# Altium schematic sheet bus_filter.SchDoc
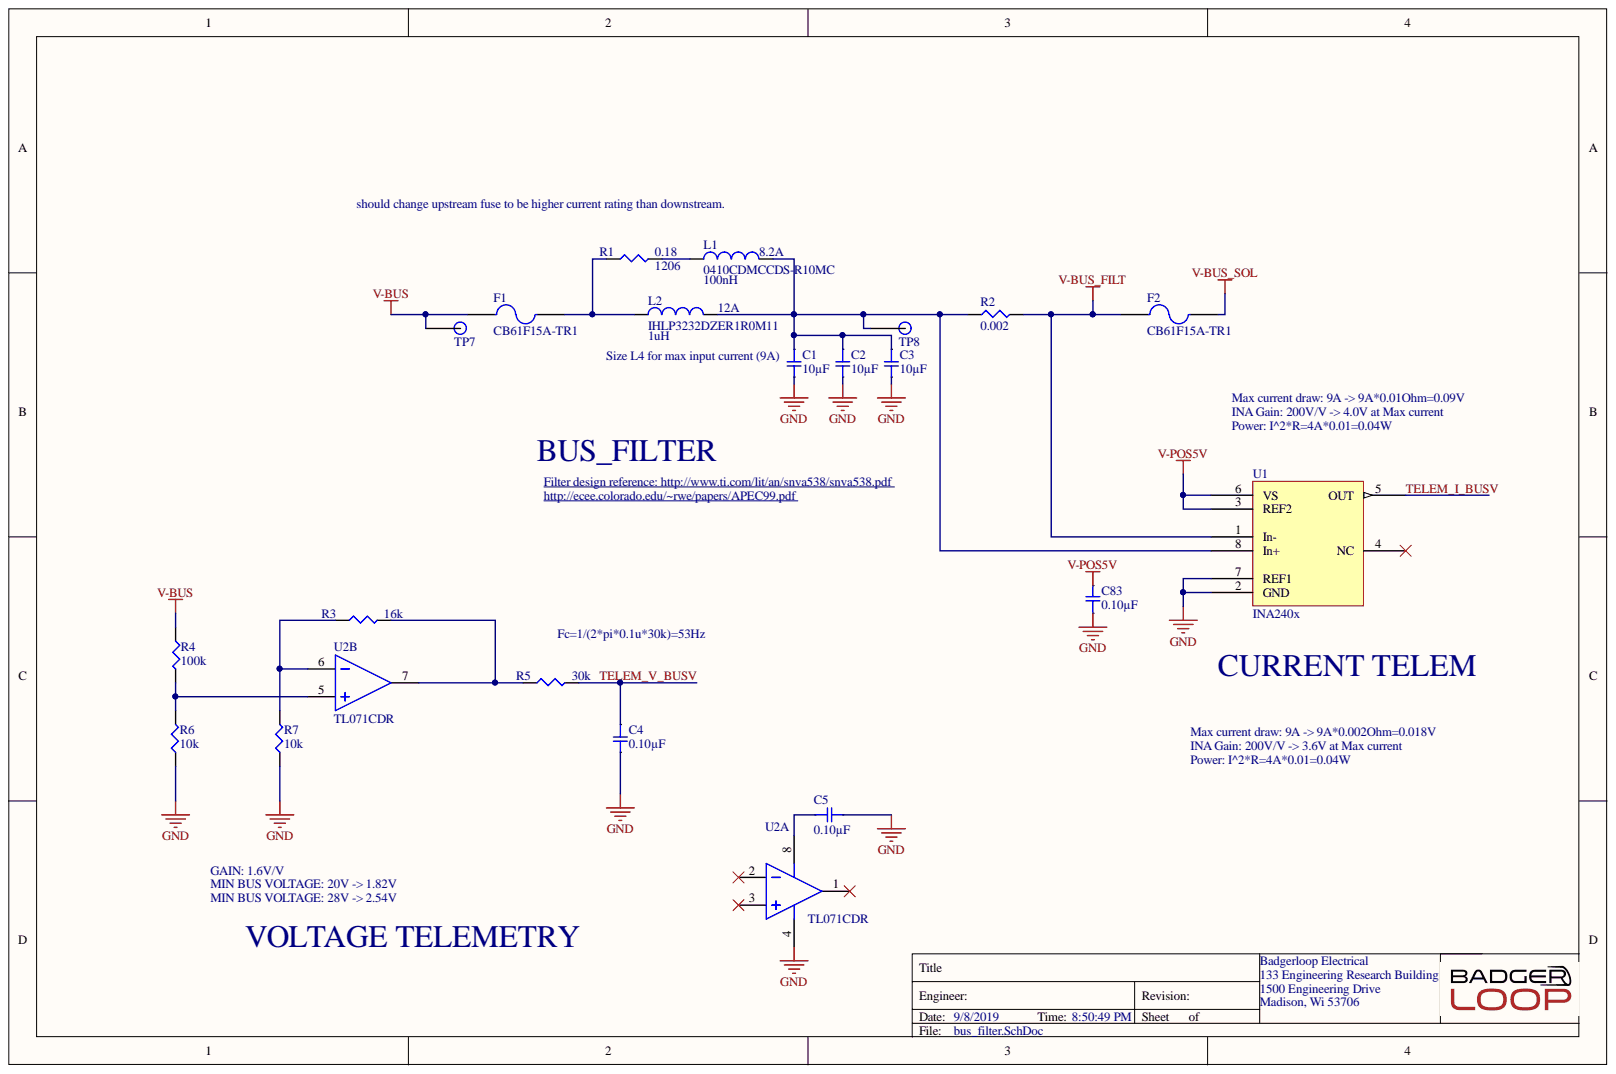
[diagram p0: bus_filter.SchDoc]

## Parts
C1  10µF  [SMD-1206C]
C2  10µF  [SMD-1206C]
C3  10µF  [SMD-1206C]
C4  0.10µF  [SMD-0805C]
C5  0.10µF  [SMD-0805C]
C83  0.10µF  [SMD-0805C]
F1  CB61F15A-TR1  [RESC6132X26N]
F2  CB61F15A-TR1  [RESC6132X26N]
L1  0410CDMCCDS-R10MC  Inductance: 100nH  Saturation Current: 8.2A  [INDM4442X20N]
L2  IHLP3232DZER1R0M11  Inductance: 1uH  Saturation Current: 12A  [INDM8682X40N]
R1  0.18  Supplier Device Package: 1206  [SMD-1206-RES]
R2  0.002  [SMD-1206-RES]
R3  16k  [SMD-0805-RES]
R4  100k  [SMD-0805-RES]
R5  30k  [SMD-0805-RES]
R6  10k  [SMD-0805-RES]
R7  10k  [SMD-0805-RES]
TP7  TP  [Test Point]
TP8  TP  [Test Point]
U1  INA240x  [SOIC127P600X175-8N]
U2  TL071CDR  [SOP127P600X175-8N]  (parts A,B)

## Nets
GND: C1.2 C2.2 C3.2 C4.2 C5.2 C83.1 R6.1 R7.1 U1.2(GND) U1.7(REF1) U2.4
V-BUS: F1.1 R4.1 TP7.1
V-BUS_FILT: F2.1 R2.2 U1.1(In-)
V-BUS_SOL: F2.2
V-POS5V: C83.2 U1.3(REF2) U1.6(VS)
TELEM_I_BUSV: U1.5(OUT)
TELEM_V_BUSV: C4.1 R5.2
NetC1_1: C1.1 C2.1 C3.1 L1.2 L2.2 R2.1 TP8.1 U1.8(In+)
NetC5_1: C5.1 U2.8
NetF1_2: F1.2 L2.1 R1.1
NetL1_1: L1.1 R1.2
NetR3_1: R3.1 R7.2 U2.6
NetR3_2: R3.2 R5.1 U2.7
NetR4_2: R4.2 R6.2 U2.5

Not connected: U1 4(NC); U2 1 2 3

## Library data of the parts
C1-C3 = GRT31CR61H106ME01L: CAP CER 10UF 50V X5R 1206; Manufacturer: Murata Electronics North America; @ qty: 0; Minimum Quantity: 1; Tolerance: ±20%; Unit Price (USD): 0.42; Applications: Automotive; Component Kind: Standard; Datasheets: http://search.murata.co.jp/Ceramy/image/img/A01X/G101/ENG/GRT31CR61H106ME01-01.pdf; Digi-Key Part Number: 490-12457-1-ND; Factory Stock: 0; Features: -; Height - Seated (Max): -; Image: //media.digikey.com/Renders/Murata%20Renders/GR331BD72E473KW01L.jpg; Lead Spacing: -; Lead Style: -; Library Name: capacitors.SchLib; Mounting Type: Surface Mount, MLCC; Operating Temperature: -55°C ~ 85°C; Package / Case: 1206 (3216 Metric); Packaging: Cut Tape (CT); Part Number: GRT31CR61H106ME01L; Part Status: Active; Quantity Available: 3394; Ratings: AEC-Q200; Series: GRT; Size / Dimension: 0.126" L x 0.063" W (3.20mm x 1.60mm); Temperature Coefficient: X5R; Thickness (Max): 0.071" (1.80mm); Value: 10µF; Voltage: 50V
C4 = GRM219R71C104KA01D: CAP CER 0.1UF 16V X7R 0805; Manufacturer: Murata Electronics North America; @ qty: 0; Minimum Quantity: 1; Tolerance: ±10%; Unit Price (USD): 0.13; Applications: General Purpose; Component Kind: Standard; Datasheets: http://www.murata.com/~/media/webrenewal/support/library/catalog/products/capacitor/mlcc/c02e.pdf; Digi-Key Part Number: 490-1683-1-ND; Factory Stock: 0; Features: -; Height - Seated (Max): -; Image: //media.digikey.com/Renders/Murata%20Renders/0805%20(2012%20Metric)%20.85mm.jpg; Lead Spacing: -; Lead Style: -; Library Name: capacitors.SchLib; Mounting Type: Surface Mount, MLCC; Operating Temperature: -55°C ~ 125°C; Package / Case: 0805 (2012 Metric); Packaging: Cut Tape (CT); Part Number: GRM219R71C104KA01D; Part Status: Active; Quantity Available: 265464; Ratings: -; Series: GRM; Size / Dimension: 0.079" L x 0.049" W (2.00mm x 1.25mm); Temperature Coefficient: X7R; Thickness (Max): 0.037" (0.95mm); Value: 0.10µF; Voltage: 16V
C5 C83 = GRM21B7U1A104JA01L: CAP CER 0.1UF 10V U2J 0805; Manufacturer: Murata Electronics North America; @ qty: 0; Minimum Quantity: 1; Tolerance: ±5%; Unit Price (USD): 0.4; Applications: General Purpose; Component Kind: Standard; Datasheets: http://www.murata.com/~/media/webrenewal/support/library/catalog/products/capacitor/mlcc/c02e.pdf; Digi-Key Part Number: 490-11592-1-ND; Factory Stock: 0; Features: -; Height - Seated (Max): -; Image: //media.digikey.com/Renders/Murata%20Renders/0805%20(2012%20Metric)%20.85mm.jpg; Lead Spacing: -; Lead Style: -; Library Name: capacitors.SchLib; Mounting Type: Surface Mount, MLCC; Operating Temperature: -55°C ~ 125°C; Package / Case: 0805 (2012 Metric); Packaging: Cut Tape (CT); Part Number: GRM21B7U1A104JA01L; Part Status: Active; Quantity Available: 3390; Ratings: -; Series: GRM; Size / Dimension: 0.079" L x 0.049" W (2.00mm x 1.25mm); Temperature Coefficient: U2J; Thickness (Max): 0.053" (1.35mm); Value: 0.10µF; Voltage: 10V
F1 F2 = CB61F15A-TR1: Manufacturer: Eaton; Approvals: cULus,PSE; Current Rating: 15A; DC Cold Resistance: 4mR; Fuse Type: Board Mount (Cartridge Style Excluded); Voltage Rating: 125V; Case/Package: SMD/SMT; Component Kind: Standard; ComponentLink1Description: http://components.arrow.com/part/dataview/0323_05918082?src-supplier=Arrow+Europe; ComponentLink1URL: http://components.arrow.com/part/dataview/0323_05918082?src-supplier=Arrow+Europe; ComponentLink2Description: http://www.cooperindustries.com/content/dam/public/bussmann/Electronics/Resources/product-datasheets/bus-elx-ds-4377-cb61f.pdf?src-supplier=Avnet+Asia; ComponentLink2URL: http://www.cooperindustries.com/content/dam/public/bussmann/Electronics/Resources/product-datasheets/bus-elx-ds-4377-cb61f.pdf?src-supplier=Avnet+Asia; ComponentLink3Description: http://www.cooperindustries.com/content/dam/public/bussmann/Electronics/Resources/product-datasheets/bus-elx-ds-4377-cb61f.pdf?src-supplier=Avnet; ComponentLink3URL: http://www.cooperindustries.com/content/dam/public/bussmann/Electronics/Resources/product-datasheets/bus-elx-ds-4377-cb61f.pdf?src-supplier=Avnet; ComponentLink4Description: http://www.cooperindustries.com/content/dam/public/bussmann/Electronics/Resources/product-datasheets/bus-elx-ds-4377-cb61f.pdf?src-supplier=Digi-Key; ComponentLink4URL: http://www.cooperindustries.com/content/dam/public/bussmann/Electronics/Resources/product-datasheets/bus-elx-ds-4377-cb61f.pdf?src-supplier=Digi-Key; Depth: 2.57mm; Height: 0.101inch; Lead Free: Lead Free; Length: 0.24inch; Library Name: misc.SchLib; Manufacturer Part Number: CB61F15A-TR1; Mount: Surface Mount; Packaging: Tape and Reel; Pricing 1: 1=1.15000, 5=1.11800, 10=1.04600, 50=0.86600, 100=0.72160, 250=0.70356, 500=0.59532 (USD); RoHS: Yes; Stock 1: 11809; Supplier 1: Digi-Key; Supplier Part Number 1: 283-4104-1-ND; Voltage Rating (AC): 125V; Voltage Rating (DC): 125V; Width: 0.101inch
L1 = 0410CDMCCDS-R10MC: Manufacturer: Sumida; Component Kind: Standard; Library Name: inductor.SchLib; Manufacturer Part Number: 0410CDMCCDS-R10MC; Pricing 1: 1=0.93000, 10=0.81700, 100=0.58360, 500=0.49024, 1000=0.39687 (USD); RoHS: No; Stock 1: 5994; Supplier 1: Digi-Key; Supplier Part Number 1: 308-2523-1-ND
L2 = IHLP3232DZER1R0M11: Fixed Inductors 1uH 20% Low Profile; Manufacturer: Vishay Dale; Core Material: Metal,Composite,Ferrite; Current Rating: 18.2A; DC Resistance (DCR): 4.63mR; Self Resonant Frequency: 49MHz; Shielding: Shielded; Test Frequency: 100kHz; Case/Package: 3232; Component Kind: Standard; ComponentLink1Description: https://datasheet.ciiva.com/6692/ihlp-3232dz-11-6692199.pdf?src-supplier=Digi-Key; ComponentLink1URL: https://datasheet.ciiva.com/6692/ihlp-3232dz-11-6692199.pdf?src-supplier=Digi-Key; ComponentLink2Description: https://datasheet.ciiva.com/6692/ihlp-3232dz-11-6692200.pdf?src-supplier=Digi-Key; ComponentLink2URL: https://datasheet.ciiva.com/6692/ihlp-3232dz-11-6692200.pdf?src-supplier=Digi-Key; ComponentLink3Description: https://datasheet.ciiva.com/6692/ihlp-3232dz-11-6692201.pdf?src-supplier=Digi-Key; ComponentLink3URL: https://datasheet.ciiva.com/6692/ihlp-3232dz-11-6692201.pdf?src-supplier=Digi-Key; ComponentLink4Description: https://datasheet.ciiva.com/17113/70231623-17113262.pdf?src-supplier=Allied+Electronics; ComponentLink4URL: https://datasheet.ciiva.com/17113/70231623-17113262.pdf?src-supplier=Allied+Electronics; Depth: 8.94mm; Height: 4mm; Height - Seated (Max): 0.157inch; Inductance Tolerance: 20%; Lead Free: Lead Free; Length: 8.64mm; Library Name: misc.SchLib; Manufacturer Part Number: IHLP3232DZER1R0M11; Max DC Current: 18.2A; Max Operating Temperature: 125°C; Military Standard: Not; Min Operating Temperature: -55°C; Mount: Surface Mount; Number of Pins: 2; Package Quantity: 500; Packaging: Tape and Reel; Pricing 1: 1=1.61000, 10=1.49500, 100=1.08100 (USD); Radiation Hardening: No; REACH SVHC: Unknown; RMS Current (Irms): 18.2A; RoHS: Yes; Stock 1: 9463; Supplier 1: Digi-Key; Supplier Part Number 1: 541-1348-1-ND; Termination: SMD/SMT; Tolerance: 20%; Width: 0.322inch
R1 = ERJ-8BSFR18V: RES SMD 0.18 OHM 1% 1/2W 1206; Manufacturer: Panasonic Electronic Components; @ qty: 1500; Factory Stock: 0; Minimum Quantity: 1; Power (Watts): 0.5W, 1/2W; Temperature Coefficient: ±250ppm/°C; Unit Price (USD): 0.11739; Component Kind: Standard; Composition: Thick Film; Datasheets: https://industrial.panasonic.com/cdbs/www-data/pdf/RDA0000/AOA0000C239.pdf; Digi-Key Part Number: P17683CT-ND; Failure Rate: -; Features: Automotive AEC-Q200, Current Sense; Height - Seated (Max): 0.028" (0.70mm); Image: //media.digikey.com/Renders/Panasonic%20Renders/ERJ-1206%20Pkg.jpg; Library Name: resistors.SchLib; Number of Terminations: 2; Operating Temperature: -55°C ~ 125°C; Package / Case: 1206 (3216 Metric); Packaging: Cut Tape (CT); Part Number: ERJ-8BSFR18V; Part Status: Active; Quantity Available: 1772; Series: ERJ; Size / Dimension: 0.126" L x 0.063" W (3.20mm x 1.60mm); Tolerance: ±1%; Value: 0.18
R2 = ERJ-MP2MF2M0U: RES SMD 0.002 OHM 1% 1W 1206; Manufacturer: Panasonic Electronic Components; @ qty: 1500; Factory Stock: 0; Minimum Quantity: 1; Temperature Coefficient: ±75ppm/°C; Unit Price (USD): 0.16926; Component Kind: Standard; Composition: Metal Element; Datasheets: https://industrial.panasonic.com/cdbs/www-data/pdf/RDA0000/AOA0000C263.pdf; Digi-Key Part Number: P19341CT-ND; Failure Rate: -; Features: Automotive AEC-Q200, Current Sense, Moisture Resistant; Height - Seated (Max): 0.035" (0.89mm); Image: //media.digikey.com/Photos/Panasonic%20Elect%20Works%20Photos/MFG_ERJ-MS4.jpg; Library Name: resistors.SchLib; Number of Terminations: 2; Operating Temperature: -65°C ~ 170°C; Package / Case: 1206 (3216 Metric); Packaging: Cut Tape (CT); Part Number: ERJ-MP2MF2M0U; Part Status: Active; Power (Watts): 1W; Quantity Available: 2730; Series: ERJ; Size / Dimension: 0.125" L x 0.063" W (3.18mm x 1.60mm); Supplier Device Package: 1206; Tolerance: ±1%; Value: 0.002
R3 = ERJ-6ENF1602V: RES SMD 16K OHM 1% 1/8W 0805; Manufacturer: Panasonic Electronic Components; @ qty: 1500; Factory Stock: 0; Minimum Quantity: 1; Power (Watts): 0.125W, 1/8W; Temperature Coefficient: ±100ppm/°C; Unit Price (USD): 0.00729; Component Kind: Standard; Composition: Thick Film; Datasheets: http://industrial.panasonic.com/www-cgi/jvcr13pz.cgi?E+PZ+3+AOA0002+ERJ6ENF1602V+7+WW; Digi-Key Part Number: P16.0KCCT-ND; Failure Rate: -; Features: Automotive AEC-Q200; Height - Seated (Max): 0.028" (0.70mm); Image: //media.digikey.com/Renders/Panasonic%20Renders/ERJ-0805%20Pkg.jpg; Library Name: resistors.SchLib; Number of Terminations: 2; Operating Temperature: -55°C ~ 155°C; Package / Case: 0805 (2012 Metric); Packaging: Cut Tape (CT); Part Number: ERJ-6ENF1602V; Part Status: Active; Quantity Available: 55239; Series: ERJ; Size / Dimension: 0.079" L x 0.049" W (2.00mm x 1.25mm); Supplier Device Package: 0805; Tolerance: ±1%; Value: 16k
R4 = ERJ-P06J104V: RES SMD 100K OHM 5% 1/2W 0805; Manufacturer: Panasonic Electronic Components; @ qty: 1500; Factory Stock: 0; Minimum Quantity: 1; Power (Watts): 0.5W, 1/2W; Temperature Coefficient: ±200ppm/°C; Unit Price (USD): 0.01839; Component Kind: Standard; Composition: Thick Film; Datasheets: https://industrial.panasonic.com/cdbs/www-data/pdf/RDA0000/AOA0000C243.pdf; Digi-Key Part Number: P100KADCT-ND; Failure Rate: -; Features: Automotive AEC-Q200, Pulse Withstanding; Height - Seated (Max): 0.028" (0.70mm); Image: //media.digikey.com/Renders/Panasonic%20Renders/ERJ-0805%20Pkg.jpg; Library Name: resistors.SchLib; Number of Terminations: 2; Operating Temperature: -55°C ~ 155°C; Package / Case: 0805 (2012 Metric); Packaging: Cut Tape (CT); Part Number: ERJ-P06J104V; Part Status: Active; Quantity Available: 82264; Series: ERJ; Size / Dimension: 0.079" L x 0.049" W (2.00mm x 1.25mm); Supplier Device Package: 0805; Tolerance: ±5%; Value: 100k
R5 = ERJ-6ENF3002V: RES SMD 30K OHM 1% 1/8W 0805; Manufacturer: Panasonic Electronic Components; @ qty: 1500; Factory Stock: 0; Minimum Quantity: 1; Power (Watts): 0.125W, 1/8W; Temperature Coefficient: ±100ppm/°C; Unit Price (USD): 0.00729; Component Kind: Standard; Composition: Thick Film; Datasheets: http://industrial.panasonic.com/www-cgi/jvcr13pz.cgi?E+PZ+3+AOA0002+ERJ6ENF3002V+7+WW; Digi-Key Part Number: P30.0KCCT-ND; Failure Rate: -; Features: Automotive AEC-Q200; Height - Seated (Max): 0.028" (0.70mm); Image: //media.digikey.com/Renders/Panasonic%20Renders/ERJ-0805%20Pkg.jpg; Library Name: resistors.SchLib; Number of Terminations: 2; Operating Temperature: -55°C ~ 155°C; Package / Case: 0805 (2012 Metric); Packaging: Cut Tape (CT); Part Number: ERJ-6ENF3002V; Part Status: Active; Quantity Available: 88046; Series: ERJ; Size / Dimension: 0.079" L x 0.049" W (2.00mm x 1.25mm); Supplier Device Package: 0805; Tolerance: ±1%; Value: 30k
R6 R7 = ERJ-P06J103V: RES SMD 10K OHM 5% 1/2W 0805; Manufacturer: Panasonic Electronic Components; @ qty: 1500; Factory Stock: 0; Minimum Quantity: 1; Power (Watts): 0.5W, 1/2W; Temperature Coefficient: ±200ppm/°C; Unit Price (USD): 0.01839; Component Kind: Standard; Composition: Thick Film; Datasheets: https://industrial.panasonic.com/cdbs/www-data/pdf/RDA0000/AOA0000C243.pdf; Digi-Key Part Number: P10KADCT-ND; Failure Rate: -; Features: Automotive AEC-Q200, Pulse Withstanding; Height - Seated (Max): 0.028" (0.70mm); Image: //media.digikey.com/Renders/Panasonic%20Renders/ERJ-0805%20Pkg.jpg; Library Name: resistors.SchLib; Number of Terminations: 2; Operating Temperature: -55°C ~ 155°C; Package / Case: 0805 (2012 Metric); Packaging: Cut Tape (CT); Part Number: ERJ-P06J103V; Part Status: Active; Quantity Available: 413576; Series: ERJ; Size / Dimension: 0.079" L x 0.049" W (2.00mm x 1.25mm); Supplier Device Package: 0805; Tolerance: ±5%; Value: 10k
TP7 TP8 = TP: SMD TEST POINT; Component Kind: Standard; Library Name: misc.SchLib
U1 = INA240x: IC AMP CURRENT SENSE 8-TSSOP; Manufacturer: TI; Manufacturer P/N: INA240A1PWR; Component Kind: Standard; Digikey Link: https://www.digikey.com/product-detail/en/texas-instruments/INA240A1PWR/296-45088-1-ND/6562101; Digikey P/N: 296-45088-1-ND; Library Name: ic.SchLib
U2 = TL071CDR: IC OPAMP JFET 3MHZ 8SOIC; Manufacturer: Texas Instruments; Common Mode Rejection Ratio: 70dB; Dual Supply Voltage: 9V; Input Bias Current: 200pA; Input Offset Voltage (Vos): 10mV; Max Dual Supply Voltage: 18V; Min Dual Supply Voltage: 3.5V; Nominal Supply Current: 2.5mA; Number of Amplifiers: 1; Operating Supply Current: 1.4mA; Slew Rate: 13V/µs; Voltage Gain: 106.02dB; Bandwidth: 3MHz; Case/Package: D; Component Kind: Standard; ComponentLink1Description: https://datasheet.ciiva.com/46439/1835036-46439448.pdf?src-supplier=Element14; ComponentLink1URL: https://datasheet.ciiva.com/46439/1835036-46439448.pdf?src-supplier=Element14; ComponentLink2Description: https://datasheet.ciiva.com/1795/tl071a-1795709.pdf?src-supplier=Digi-Key; ComponentLink2URL: https://datasheet.ciiva.com/1795/tl071a-1795709.pdf?src-supplier=Digi-Key; ComponentLink3Description: https://datasheet.ciiva.com/14456/tl074-14456719.pdf?src-supplier=Avnet; ComponentLink3URL: https://datasheet.ciiva.com/14456/tl074-14456719.pdf?src-supplier=Avnet; ComponentLink4Description: https://datasheet.ciiva.com/26243/tl074-26243627.pdf?src-supplier=Avnet+Europe; ComponentLink4URL: https://datasheet.ciiva.com/26243/tl074-26243627.pdf?src-supplier=Avnet+Europe; ComponentLink5Description: https://datasheet.ciiva.com/1795/tl071a-1795710.pdf?src-supplier=Digi-Key; ComponentLink5URL: https://datasheet.ciiva.com/1795/tl071a-1795710.pdf?src-supplier=Digi-Key; ComponentLink6Description: https://datasheet.ciiva.com/1795/tl071a-1795711.pdf?src-supplier=Digi-Key; ComponentLink6URL: https://datasheet.ciiva.com/1795/tl071a-1795711.pdf?src-supplier=Digi-Key; Contact Plating: Gold; Gain Bandwidth Product: 3MHz; Height: 1.58mm; Lead Free: Lead Free; Length: 4.9mm; Library Name: ic.SchLib; Manufacturer Part Number: TL071CDR; Max I/O Voltage: 10mV; Max Operating Temperature: 85°C; Max Supply Voltage: 18V; Min Operating Temperature: -40°C; Nominal Gain Bandwidth Product: 3MHz; Number of Channels: 1; Number of Circuits: 1; Number of Elements: 1; Number of Pins: 8; Package Quantity: 1; Packaging: Tape and Reel; Pricing 1: 1=0.47000, 10=0.40200, 100=0.29990, 500=0.23566, 1000=0.18210 (USD); Radiation Hardening: No; REACH SVHC: No SVHC; RoHS: Yes; Stock 1: 8447; Supplier 1: Digi-Key; Supplier Part Number 1: 296-14994-1-ND; Unity Gain Bandwidth Product: 3MHz; Width: 3.91mm
